# Altium schematic sheet [03] - MCU and Conn.SchDoc (continued)

## Library data of the parts
ANT1 = 2450AT18D0100E: RF ANT 2.4GHZ CHIP SOLDER SMD; Manufacturer: Johanson; Bandwidth: 100MHz; Frequency: 2.45GHz; Gain: -1dB; Impedance: 50R; Max Power Dissipation: 2W; Number of Bands: 1; Return Loss: -10dB; Case/Package: SMD/SMT; Category: RF Antennas; Component Kind: Standard; ComponentLink1Description: https://datasheet.ciiva.com/29647/2450at18d0100-29647392.pdf?src-supplier=Digi-Key; ComponentLink1URL: https://datasheet.ciiva.com/29647/2450at18d0100-29647392.pdf?src-supplier=Digi-Key; ComponentLink2Description: https://datasheet.ciiva.com/24046/antenna-2450at18d0100-v3-258090-24046431.pdf?src-supplier=Mouser; ComponentLink2URL: https://datasheet.ciiva.com/24046/antenna-2450at18d0100-v3-258090-24046431.pdf?src-supplier=Mouser; Height: 0.047inch; Length: 3.2mm; Library Name: Schlib-master.SchLib; Manufacturer Part Number: 2450AT18D0100E; Mount: Surface Mount; Package Quantity: 3000; Packaging: Tape and Reel; Power Rating: 2W; Pricing 1: 1=0.67000, 10=0.58900, 25=0.55360, 100=0.45190, 250=0.41972, 500=0.35720, 1000=0.28576 (USD); Radiation Hardening: No; RoHS: Yes; RoHS Compliant: Yes; Series: -; Stock 1: 488138; Supplier 1: Digi-Key; Supplier Part Number 1: 712-1573-1-ND; Termination: Solder; Width: 1.6mm
C1 C4 C12 C16 = CL05A475MP5NRNC: CAP CER 4.7UF 10V X5R 0402; Manufacturer: Samsung; Tolerance: 20%; Capacitance: 4.7uF; Case Code (Imperial): 0402; Case/Package: 0402; Category: Ceramic Capacitors; Component Kind: Standard; ComponentLink1Description: https://datasheet.ciiva.com/25786/cl05a475mp5nrnc-25786963.pdf?src-supplier=Digi-Key; ComponentLink1URL: https://datasheet.ciiva.com/25786/cl05a475mp5nrnc-25786963.pdf?src-supplier=Digi-Key; ComponentLink2Description: https://datasheet.ciiva.com/16437/cl05b104kq5nnnc-16437353.pdf?src-supplier=Future+Electronics; ComponentLink2URL: https://datasheet.ciiva.com/16437/cl05b104kq5nnnc-16437353.pdf?src-supplier=Future+Electronics; Dielectric: X5R; Lead Free: Lead Free; Length: 0.039inch; Library Name: Schlib-master.SchLib; Manufacturer Part Number: CL05A475MP5NRNC; Max Operating Temperature: 85°C; Min Operating Temperature: -55°C; Mount: Surface Mount; Package Quantity: 10000; Packaging: Tape and Reel; Pricing 1: 1=0.10000, 10=0.06800, 50=0.03680, 100=0.03010, 500=0.02150, 1000=0.01689, 2500=0.01535, 5000=0.01412 (USD); RoHS: Yes; RoHS Compliant: Yes; Series: CL; Stock 1: 6997940; Supplier 1: Digi-Key; Supplier Part Number 1: 1276-1482-1-ND; Thickness: 0.022inch; Voltage Rating: 10V; Width: 0.02inch
C2 C5 C6 C13-C15 C18 C22 = GRM155R71H104KE14D: CAP CER 0.1UF 50V X7R 0402; Manufacturer: Murata; Dielectric Material: Ceramic; Dissipation Factor: 0.025%; Tolerance: 10%; Capacitance: 100nF; Case Code (Imperial): 0402; Case/Package: 0402; Category: Ceramic Capacitors; Component Kind: Standard; ComponentLink1Description: http://www.mouser.com/ds/2/281/c02e-2905.pdf?src-supplier=Mouser; ComponentLink1URL: http://www.mouser.com/ds/2/281/c02e-2905.pdf?src-supplier=Mouser; ComponentLink2Description: http://www.farnell.com/datasheets/2079156.pdf?src-supplier=Farnell; ComponentLink2URL: http://www.farnell.com/datasheets/2079156.pdf?src-supplier=Farnell; ComponentLink3Description: http://components.arrow.com/part/dataview/0323_07030614?src-supplier=Arrow+Europe; ComponentLink3URL: http://components.arrow.com/part/dataview/0323_07030614?src-supplier=Arrow+Europe; ComponentLink4Description: http://psearch.en.murata.com/capacitor/product/GRM155R71H104KE14%23.pdf?src-supplier=Avnet; ComponentLink4URL: http://psearch.en.murata.com/capacitor/product/GRM155R71H104KE14%23.pdf?src-supplier=Avnet; ComponentLink5Description: https://search.murata.co.jp/Ceramy/image/img/A01X/G101/ENG/GRM155R71H104KE14-01.pdf?src-supplier=Digi-Key; ComponentLink5URL: https://search.murata.co.jp/Ceramy/image/img/A01X/G101/ENG/GRM155R71H104KE14-01.pdf?src-supplier=Digi-Key; ComponentLink6Description: http://www.farnell.com/datasheets/2079156.pdf?src-supplier=Newark; ComponentLink6URL: http://www.farnell.com/datasheets/2079156.pdf?src-supplier=Newark; ComponentLink7Description: http://www.farnell.com/datasheets/2079156.pdf?src-supplier=Element14; ComponentLink7URL: http://www.farnell.com/datasheets/2079156.pdf?src-supplier=Element14; Depth: 0.5mm; Dielectric: X7R; Height: 0.5mm; Lead Free: Lead Free; Length: 1mm; Library Name: Schlib-master.SchLib; Manufacturer Part Number: GRM155R71H104KE14D; Material: Ceramic; Max Operating Temperature: 125°C; Min Operating Temperature: -55°C; Mount: Surface Mount; Package Quantity: 10000; Packaging: Tape and Reel; Pricing 1: 1=0.10000, 10=0.03400, 50=0.01860, 100=0.01520, 500=0.01086, 1000=0.00854, 2500=0.00776, 5000=0.00714 (USD); REACH SVHC: No SVHC; Resistor Type: Ceramic; RoHS: Yes; RoHS Compliant: Yes; Stock 1: 23318607; Supplier 1: Digi-Key; Supplier Part Number 1: 490-10700-1-ND; Termination: SMD/SMT; Thickness: 0.022inch; Voltage: 50V; Voltage Rating: 50V; Voltage Rating (DC): 50V; Weight: 0.000212oz; Width: 0.5mm
C3 C7 C10 C11 C20 = CL05A105KO5NNNC: CAP CER 1UF 16V X5R 0402; Manufacturer: Samsung; Tolerance: 10%; Capacitance: 1uF; Case Code (Imperial): 0402; Case/Package: 0402; Category: Ceramic Capacitors; Component Kind: Standard; ComponentLink1Description: https://datasheet.ciiva.com/16207/cl05a105ko5nnnc-16207571.pdf?src-supplier=Future+Electronics; ComponentLink1URL: https://datasheet.ciiva.com/16207/cl05a105ko5nnnc-16207571.pdf?src-supplier=Future+Electronics; ComponentLink2Description: https://datasheet.ciiva.com/15195/getdatasheetpartid-355272-15195629.pdf?src-supplier=Verical; ComponentLink2URL: https://datasheet.ciiva.com/15195/getdatasheetpartid-355272-15195629.pdf?src-supplier=Verical; Contact Plating: Tin; Depth: 0.5mm; Dielectric: X5R; Height: 0.5mm; Length: 1mm; Library Name: Schlib-master.SchLib; Manufacturer Part Number: CL05A105KO5NNNC; Max Operating Temperature: 85°C; Min Operating Temperature: -55°C; Mount: Surface Mount; Package Quantity: 1; Packaging: Tape and Reel; Pricing 1: 1=0.10000, 10=0.04400, 50=0.02400, 100=0.01960, 500=0.01402, 1000=0.01101, 2500=0.01001, 5000=0.00921 (USD); Radiation Hardening: No; RoHS: Yes; Stock 1: 2455652; Supplier 1: Digi-Key; Supplier Part Number 1: 1276-1067-1-ND; Thickness: 0.022inch; Voltage: 16V; Voltage Rating: 16V; Width: 0.02inch
C8 = GJM1555C1HR80BB01D: CAP CER 0.8PF 50V C0G/NP0 0402; Manufacturer: Murata; Dielectric: C0G; Capacitance: 0.8pF; Case Code (Imperial): 0402; Case/Package: 0402; Category: Ceramic Capacitors; Component Kind: Standard; ComponentLink1Description: https://datasheet.ciiva.com/6270/product-6270516.pdf?src-supplier=Digi-Key; ComponentLink1URL: https://datasheet.ciiva.com/6270/product-6270516.pdf?src-supplier=Digi-Key; ComponentLink2Description: https://datasheet.ciiva.com/18183/getdatasheetpartid-75095-18183863.pdf?src-supplier=Verical; ComponentLink2URL: https://datasheet.ciiva.com/18183/getdatasheetpartid-75095-18183863.pdf?src-supplier=Verical; ComponentLink3Description: https://datasheet.ciiva.com/6270/partnumbering-e-01-6270517.pdf?src-supplier=Digi-Key; ComponentLink3URL: https://datasheet.ciiva.com/6270/partnumbering-e-01-6270517.pdf?src-supplier=Digi-Key; ComponentLink4Description: https://datasheet.ciiva.com/16440/l0005e-16440469.pdf?src-supplier=Future+Electronics; ComponentLink4URL: https://datasheet.ciiva.com/16440/l0005e-16440469.pdf?src-supplier=Future+Electronics; ComponentLink5Description: https://datasheet.ciiva.com/11616/product-11616838.pdf?src-supplier=Avnet; ComponentLink5URL: https://datasheet.ciiva.com/11616/product-11616838.pdf?src-supplier=Avnet; ComponentLink6Description: https://datasheet.ciiva.com/6270/partnumbering-e-01-6270552.pdf?src-supplier=Digi-Key; ComponentLink6URL: https://datasheet.ciiva.com/6270/partnumbering-e-01-6270552.pdf?src-supplier=Digi-Key; Construction: Flat; Depth: 0.5mm; Height: 0.5mm; Lead Free: Lead Free; Length: 1mm; Library Name: Schlib-master.SchLib; Manufacturer Part Number: GJM1555C1HR80BB01D; Max Operating Temperature: 125°C; Min Operating Temperature: -55°C; Mount: Surface Mount; Package Quantity: 1; Packaging: Tape and Reel; Pricing 1: 1=0.20000, 10=0.13900, 50=0.07920, 100=0.06560, 500=0.04596, 1000=0.03805, 2500=0.03542, 5000=0.03240 (USD); Radiation Hardening: No; RoHS: Yes; RoHS Compliant: Yes; Series: GJM; Stock 1: 91134; Supplier 1: Digi-Key; Supplier Part Number 1: 490-6080-1-ND; Termination: SMD/SMT; Thickness: 0.022inch; Voltage: 50V; Voltage Rating: 50V; Voltage Rating (DC): 50V; Width: 0.02inch
C9 = GJM1555C1HR50BB01D: CAP CER 0.5PF 50V C0G/NP0 0402; Manufacturer: Murata; Dielectric: C0G; Temperature Coefficient: 30ppm/°C; Capacitance: 0.5pF; Case Code (Imperial): 0402; Case/Package: 0402; Category: Ceramic Capacitors; Component Kind: Standard; ComponentLink1Description: https://datasheet.ciiva.com/6270/product-6270544.pdf?src-supplier=Digi-Key; ComponentLink1URL: https://datasheet.ciiva.com/6270/product-6270544.pdf?src-supplier=Digi-Key; ComponentLink2Description: https://datasheet.ciiva.com/11789/0900766b80ef921d-11789028.pdf?src-supplier=RSComponents; ComponentLink2URL: https://datasheet.ciiva.com/11789/0900766b80ef921d-11789028.pdf?src-supplier=RSComponents; ComponentLink3Description: https://datasheet.ciiva.com/5694/1700184-5694998.pdf?src-supplier=Farnell; ComponentLink3URL: https://datasheet.ciiva.com/5694/1700184-5694998.pdf?src-supplier=Farnell; ComponentLink4Description: https://datasheet.ciiva.com/18183/getdatasheetpartid-153078-18183862.pdf?src-supplier=Verical; ComponentLink4URL: https://datasheet.ciiva.com/18183/getdatasheetpartid-153078-18183862.pdf?src-supplier=Verical; ComponentLink5Description: https://datasheet.ciiva.com/8030/1303334-8030134.pdf?src-supplier=Element14; ComponentLink5URL: https://datasheet.ciiva.com/8030/1303334-8030134.pdf?src-supplier=Element14; ComponentLink6Description: https://datasheet.ciiva.com/16392/l0005e-16392585.pdf?src-supplier=Future+Electronics; ComponentLink6URL: https://datasheet.ciiva.com/16392/l0005e-16392585.pdf?src-supplier=Future+Electronics; ComponentLink7Description: https://datasheet.ciiva.com/17451/product-17451306.pdf?src-supplier=Avnet+Europe; ComponentLink7URL: https://datasheet.ciiva.com/17451/product-17451306.pdf?src-supplier=Avnet+Europe; ComponentLink8Description: https://datasheet.ciiva.com/11617/product-11617149.pdf?src-supplier=Avnet; ComponentLink8URL: https://datasheet.ciiva.com/11617/product-11617149.pdf?src-supplier=Avnet; ComponentLink9Description: https://datasheet.ciiva.com/6270/partnumbering-e-01-6270543.pdf?src-supplier=Digi-Key; ComponentLink9URL: https://datasheet.ciiva.com/6270/partnumbering-e-01-6270543.pdf?src-supplier=Digi-Key; Construction: Flat; Depth: 0.5mm; Height: 0.5mm; Lead Free: Lead Free; Lead Pitch: 0.3mm; Length: 1mm; Library Name: Schlib-master.SchLib; Manufacturer Part Number: GJM1555C1HR50BB01D; Max Operating Temperature: 125°C; Min Operating Temperature: -55°C; Mount: Surface Mount; Number of Pins: 2; Package Quantity: 1; Packaging: Tape and Reel; Pricing 1: 1=0.20000, 10=0.13900, 50=0.07920, 100=0.06560, 500=0.04596, 1000=0.03805, 2500=0.03542, 5000=0.03240 (USD); Radiation Hardening: No; REACH SVHC: No SVHC; RoHS: Yes; RoHS Compliant: Yes; Series: GJM; Stock 1: 81547; Supplier 1: Digi-Key; Supplier Part Number 1: 490-6077-1-ND; Termination: SMD/SMT; Thickness: 0.022inch; Voltage: 50V; Voltage Rating: 50V; Voltage Rating (DC): 50V; Width: 0.02inch
C17 C23 = GRM1555C1H8R0DA01D: CAP CER 8PF 50V C0G/NP0 0402; Manufacturer: Murata; Dielectric: C0G; Material: Ceramic; Temperature Coefficient: 30ppm/°C; Capacitance: 8pF; Case Code (Imperial): 0402; Case/Package: 0402; Category: Ceramic Capacitors; Component Kind: Standard; ComponentLink1Description: https://datasheet.ciiva.com/5420/product-5420945.pdf?src-supplier=Mouser; ComponentLink1URL: https://datasheet.ciiva.com/5420/product-5420945.pdf?src-supplier=Mouser; ComponentLink2Description: https://datasheet.ciiva.com/18184/getdatasheetpartid-713257-18184503.pdf?src-supplier=Verical; ComponentLink2URL: https://datasheet.ciiva.com/18184/getdatasheetpartid-713257-18184503.pdf?src-supplier=Verical; ComponentLink3Description: https://datasheet.ciiva.com/6277/partnumbering-e-01-6277815.pdf?src-supplier=Digi-Key; ComponentLink3URL: https://datasheet.ciiva.com/6277/partnumbering-e-01-6277815.pdf?src-supplier=Digi-Key; ComponentLink4Description: https://datasheet.ciiva.com/6277/partnumbering-e-01-6277814.pdf?src-supplier=Digi-Key; ComponentLink4URL: https://datasheet.ciiva.com/6277/partnumbering-e-01-6277814.pdf?src-supplier=Digi-Key; ComponentLink5Description: https://datasheet.ciiva.com/6277/partnumbering-e-01-6277816.pdf?src-supplier=Digi-Key; ComponentLink5URL: https://datasheet.ciiva.com/6277/partnumbering-e-01-6277816.pdf?src-supplier=Digi-Key; ComponentLink6Description: https://datasheet.ciiva.com/11463/product-11463855.pdf?src-supplier=Avnet; ComponentLink6URL: https://datasheet.ciiva.com/11463/product-11463855.pdf?src-supplier=Avnet; Construction: Flat; Depth: 0.5mm; Height: 0.5mm; Lead Free: Lead Free; Length: 1mm; Library Name: Schlib-master.SchLib; Manufacturer Part Number: GRM1555C1H8R0DA01D; Max Operating Temperature: 125°C; Min Operating Temperature: -55°C; Mount: Surface Mount; Package Quantity: 1; Packaging: Tape and Reel; Pricing 1: 1=0.10000, 10=0.03400, 50=0.01840, 100=0.01510, 500=0.01078, 1000=0.00847, 2500=0.00770, 5000=0.00708 (USD); Radiation Hardening: No; Reach SVHC Compliant: Yes; RoHS: Yes; RoHS Compliant: Yes; Series: GRM; Stock 1: 709625; Supplier 1: Digi-Key; Supplier Part Number 1: 490-5867-1-ND; Termination: SMD/SMT; Thickness: 0.022inch; Voltage: 50V; Voltage Rating: 50V; Voltage Rating (DC): 50V; Width: 0.5mm
C19 = CL05B102KB5NNNC: CAP CER 1000PF 50V X7R 0402; Manufacturer: Samsung; Material: Ceramic; Tolerance: 10%; Capacitance: 1nF; Case Code (Imperial): 0402; Case/Package: 0402; Category: Ceramic Capacitors; Component Kind: Standard; ComponentLink1Description: https://datasheet.ciiva.com/25806/cl05b102kb5nnnc-25806896.pdf?src-supplier=Digi-Key; ComponentLink1URL: https://datasheet.ciiva.com/25806/cl05b102kb5nnnc-25806896.pdf?src-supplier=Digi-Key; ComponentLink2Description: https://datasheet.ciiva.com/15227/getdatasheetpartid-355276-15227190.pdf?src-supplier=Verical; ComponentLink2URL: https://datasheet.ciiva.com/15227/getdatasheetpartid-355276-15227190.pdf?src-supplier=Verical; ComponentLink3Description: https://datasheet.ciiva.com/29198/459222-da-01-en-vs-kondensator-0402-29198191.pdf?src-supplier=Conrad; ComponentLink3URL: https://datasheet.ciiva.com/29198/459222-da-01-en-vs-kondensator-0402-29198191.pdf?src-supplier=Conrad; ComponentLink4Description: https://datasheet.ciiva.com/26309/mlcc-catalogdownload2013-eng-26309020.pdf?src-supplier=Distrelec; ComponentLink4URL: https://datasheet.ciiva.com/26309/mlcc-catalogdownload2013-eng-26309020.pdf?src-supplier=Distrelec; Contact Plating: Tin; Depth: 0.5mm; Dielectric: X7R; Height: 0.5mm; Lead Free: Lead Free; Length: 1mm; Library Name: Schlib-master.SchLib; Manufacturer Part Number: CL05B102KB5NNNC; Max Operating Temperature: 125°C; Min Operating Temperature: -55°C; Mount: Surface Mount; Package Quantity: 1; Packaging: Tape and Reel; Pricing 1: 1=0.10000, 10=0.03300, 50=0.01800, 100=0.01480, 500=0.01054, 1000=0.00829, 2500=0.00754, 5000=0.00693 (USD); Radiation Hardening: No; RoHS: Yes; Stock 1: 12036728; Supplier 1: Digi-Key; Supplier Part Number 1: 1276-1032-6-ND; Thickness: 0.022inch; Voltage: 50V; Voltage Rating: 50V; Voltage Rating (DC): 50V; Width: 0.02inch
C21 = CL05C101JB5NNNC: CAP CER 100PF 50V C0G/NP0 0402; Manufacturer: Samsung; Dielectric: C0G; Material: Ceramic; Temperature Coefficient: 300ppm/°C; Tolerance: 5%; Capacitance: 100pF; Case Code (Imperial): 0402; Case/Package: 0402; Category: Ceramic Capacitors; Component Kind: Standard; ComponentLink1Description: https://datasheet.ciiva.com/15195/getdatasheetpartid-357528-15195665.pdf?src-supplier=Verical; ComponentLink1URL: https://datasheet.ciiva.com/15195/getdatasheetpartid-357528-15195665.pdf?src-supplier=Verical; ComponentLink2Description: https://datasheet.ciiva.com/29198/458492-da-01-en-vs-kondensator-0402-29198529.pdf?src-supplier=Conrad; ComponentLink2URL: https://datasheet.ciiva.com/29198/458492-da-01-en-vs-kondensator-0402-29198529.pdf?src-supplier=Conrad; ComponentLink3Description: https://datasheet.ciiva.com/16379/cl05b104kq5nnnc-16379226.pdf?src-supplier=Future+Electronics; ComponentLink3URL: https://datasheet.ciiva.com/16379/cl05b104kq5nnnc-16379226.pdf?src-supplier=Future+Electronics; Construction: Flat; Contact Plating: Tin; Depth: 0.5mm; Height: 0.5mm; Length: 1mm; Library Name: Schlib-master.SchLib; Manufacturer Part Number: CL05C101JB5NNNC; Max Operating Temperature: 125°C; Min Operating Temperature: -55°C; Mount: Surface Mount; Package Quantity: 10000; Packaging: Tape and Reel; Pricing 1: 1=0.10000, 10=0.02000, 50=0.01080, 100=0.00880, 500=0.00628, 1000=0.00493, 2500=0.00448, 5000=0.00412 (USD); Radiation Hardening: No; RoHS: Yes; RoHS Compliant: Yes; Series: CL; Stock 1: 4859529; Supplier 1: Digi-Key; Supplier Part Number 1: 1276-1025-1-ND; Thickness: 0.022inch; Voltage: 50V; Voltage Rating: 50V; Width: 0.02inch
D1 = CUHS20F30,H3F: DIODE SCHOTTKY 30V 2A US2H; Manufacturer: Toshiba; Component Kind: Standard; Library Name: Schlib-master.SchLib; Manufacturer Part Number: CUHS20F30,H3F; Pricing 1: 1=0.37000, 10=0.30200, 100=0.20540, 500=0.15408, 1000=0.11556 (USD); RoHS: No; Stock 1: 70970; Supplier 1: Digi-Key; Supplier Part Number 1: CUHS20F30H3FCT-ND
D2 D3 = TPESD0201G05V: TVS DIODE 5.5VWM 40VC 0201; Manufacturer: TECH PUBLIC; Clamping Voltage: 40V; Number of Bidirectional Channels: 1; Peak Pulse Current: 3A; Power Line Protection: No; Reverse Standoff Voltage: 5.5V; Case/Package: SMD/SMT; Category: Diodes; Component Kind: Standard; ComponentLink1Description: https://datasheet.lcsc.com/lcsc/2005211235_TECH-PUBLIC-TPESD0201G15V_C558460.pdf; ComponentLink1URL: https://datasheet.lcsc.com/lcsc/2005211235_TECH-PUBLIC-TPESD0201G15V_C558460.pdf; Halogen Free: Halogen Free; Lead Free: Lead Free; Library Name: Schlib-master.SchLib; Manufacturer Part Number: TPESD0201G05V; Max Operating Temperature: 125°C; Min Operating Temperature: -55°C; Mount: Surface Mount; Packaging: Tape and Reel; Resistor Type: Zener; RoHS: Yes; RoHS Compliant: Yes
D4 = 19-217/G7C-AN1P2/3T: LED YLW/GREEN DIFFUSED 1608 SMD; Manufacturer: Everlight; Color: Green,Yellow; Forward Voltage: 2V; Lens Style: Clear,Diffused,Colorless,Rectangular; Number of LEDs: 1; Viewing Angle: 120°; Category: LEDs; Component Kind: Standard; ComponentLink1Description: https://datasheet.ciiva.com/48679/19-217-g7c-an1p2-3t-48679179.pdf?src-supplier=Avnet; ComponentLink1URL: https://datasheet.ciiva.com/48679/19-217-g7c-an1p2-3t-48679179.pdf?src-supplier=Avnet; Lead Free: Lead Free; Library Name: Schlib-master.SchLib; Manufacturer Part Number: 19-217/G7C-AN1P2/3T; Mounting Technology: Surface Mount; Pins: 2; Pricing 1: 2635=0.01900, 6250=0.01600, 10000=0.01500, 13335=0.01500, 17860=0.01400, 23080=0.01300 (USD); RoHS: Yes; RoHS Compliant: Yes; Stock 1: 152500; Supplier 1: Win Source Electronics; Supplier Part Number 1: 5203-19-217/G7C-AN1P2/3T
J1 = TYPEC-324G-BCP24: CONN RCPT USB TYPE C, SMT PINS, TH SHIELD; Manufacturer: XUNPU; Category: USB Connectors; Component Kind: Standard; ComponentLink1Description: https://www.lcsc.com/product-detail/USB-Connectors_XUNPU-TYPEC-324G-BCP24_C920230.html; ComponentLink1URL: https://www.lcsc.com/product-detail/USB-Connectors_XUNPU-TYPEC-324G-BCP24_C920230.html; Library Name: Schlib-master.SchLib; Manufacturer Part Number: TYPEC-324G-BCP24
L1 = LQG15HN4N7S02D: FIXED IND 4.7NH 700MA 230 MOHM; Manufacturer: Murata; Core Material: Air; Current Rating: 300mA; DC Resistance (DCR): 230mR; Inductor Type: MONOLITHIC; Q Factor: 8; Self Resonant Frequency: 6GHz; Shielding: Unshielded; Test Frequency: 100MHz; Case Code (Imperial): 0402; Case Code (Metric): 1005; Case/Package: 0402; Category: Fixed Inductors; Component Kind: Standard; ComponentLink1Description: https://datasheet.ciiva.com/11801/0900766b80f10808-11801428.pdf?src-supplier=RSComponents; ComponentLink1URL: https://datasheet.ciiva.com/11801/0900766b80f10808-11801428.pdf?src-supplier=RSComponents; ComponentLink2Description: https://datasheet.ciiva.com/8509/l0075s0083lqg15hn-8509689.pdf?src-supplier=Avnet; ComponentLink2URL: https://datasheet.ciiva.com/8509/l0075s0083lqg15hn-8509689.pdf?src-supplier=Avnet; ComponentLink3Description: https://datasheet.ciiva.com/4976/o05e-4976780.pdf?src-supplier=Mouser; ComponentLink3URL: https://datasheet.ciiva.com/4976/o05e-4976780.pdf?src-supplier=Mouser; ComponentLink4Description: https://datasheet.ciiva.com/8002/66026-8002543.pdf?src-supplier=Element14; ComponentLink4URL: https://datasheet.ciiva.com/8002/66026-8002543.pdf?src-supplier=Element14; ComponentLink5Description: https://datasheet.ciiva.com/1063/l0075s0083lqg15hn-1063843.pdf?src-supplier=Digi-Key; ComponentLink5URL: https://datasheet.ciiva.com/1063/l0075s0083lqg15hn-1063843.pdf?src-supplier=Digi-Key; ComponentLink6Description: https://datasheet.ciiva.com/8510/l0075s0083lqg15hn-8510764.pdf?src-supplier=Avnet; ComponentLink6URL: https://datasheet.ciiva.com/8510/l0075s0083lqg15hn-8510764.pdf?src-supplier=Avnet; DC Current: 300mA; Depth: 0.55mm; Height: 0.02inch; Height - Seated (Max): 0.022inch; Inductance: 4.7nH; Lead Free: Lead Free; Length: 1mm; Library Name: Schlib-master.SchLib; Manufacturer Part Number: LQG15HN4N7S02D; Max DC Current: 300mA; Max Operating Temperature: 125°C; Military Standard: Not; Min Operating Temperature: -55°C; Mount: Surface Mount; Number of Pins: 2; Package Quantity: 10000; Packaging: Tape and Reel; Pricing 1: 1=0.10000, 10=0.05300, 25=0.04680, 50=0.03980, 100=0.03510, 250=0.03216, 500=0.02924, 1000=0.02457, 2500=0.02106 (USD); Radiation Hardening: No; Rated Current-Max: 300mA; REACH SVHC: No SVHC; RoHS: Yes; RoHS Compliant: Yes; Series: LQG15HS; Stock 1: 37375; Supplier 1: Digi-Key; Supplier Part Number 1: 490-1080-1-ND; Termination: SMD/SMT; Width: 0.02inch
OSC1 = SIT1532AC-J5-DCC-32.768E: MEMS OSC XO 32.7680KHZ LVCMOS; Manufacturer: SiTime; Frequency: 32.768kHz; Frequency Stability: 75ppm; Max Supply Current: 1.3uA; Category: Oscillators; Component Kind: Standard; ComponentLink1Description: http://www.sitime.com/products/datasheets/sit1532/SiT1532-datasheet.pdf?src-supplier=Digi-Key; ComponentLink1URL: http://www.sitime.com/products/datasheets/sit1532/SiT1532-datasheet.pdf?src-supplier=Digi-Key; ComponentLink2Description: https://www.sitime.com/datasheet/SiT1532?src-supplier=Digi-Key; ComponentLink2URL: https://www.sitime.com/datasheet/SiT1532?src-supplier=Digi-Key; Height: 0.024inch; Length: 0.061inch; Library Name: Schlib-master.SchLib; Manufacturer Part Number: SIT1532AC-J5-DCC-32.768E; Max Operating Temperature: 70°C; Min Operating Temperature: -10°C; Mount: Surface Mount; Packaging: Tape and Reel; Pricing 1: 1=1.25000, 10=1.10300, 50=1.03120, 100=0.91120, 500=0.86328 (USD); RoHS: Yes; Stock 1: 4488; Supplier 1: Digi-Key; Supplier Part Number 1: 1473-1313-1-ND; Width: 0.033inch
Q1 = EM6M2T2R: MOSFET N/P-CH 20V 0.2A EMT6; Manufacturer: Rohm; Continuous Drain Current (ID): 200mA; Drain to Source Resistance: 1R; FET Type: N and P-Channel; Input Capacitance: 25pF; Max Power Dissipation: 150mW; Case/Package: SOT-23-5; Category: MOSFETs; Component Kind: Standard; ComponentLink1Description: https://datasheet.ciiva.com/6387/em6m2-6387413.pdf?src-supplier=Digi-Key; ComponentLink1URL: https://datasheet.ciiva.com/6387/em6m2-6387413.pdf?src-supplier=Digi-Key; ComponentLink2Description: https://datasheet.ciiva.com/6387/em6m2-6387415.pdf?src-supplier=Digi-Key; ComponentLink2URL: https://datasheet.ciiva.com/6387/em6m2-6387415.pdf?src-supplier=Digi-Key; ComponentLink3Description: https://datasheet.ciiva.com/6387/em6m2-6387417.pdf?src-supplier=Digi-Key; ComponentLink3URL: https://datasheet.ciiva.com/6387/em6m2-6387417.pdf?src-supplier=Digi-Key; Drain to Source Voltage (Vdss): 20V; Drain-source On Resistance-Max: 1R; Lead Free: Lead Free; Library Name: Schlib-master.SchLib; Manufacturer Part Number: EM6M2T2R; Max Operating Temperature: 150°C; Min Operating Temperature: -55°C; Mounting Technology: Surface Mount; Package Quantity: 1; Packaging: Tape and Reel; Pins: 6; Pricing 1: 1=0.58000, 10=0.49600, 100=0.37030, 500=0.29096, 1000=0.22482, 2000=0.20499 (USD); Radiation Hardening: No; Rds On Max: 1R; RoHS: Yes; RoHS Compliant: Yes; Stock 1: 90900; Supplier 1: Digi-Key; Supplier Part Number 1: EM6M2T2RCT-ND
Q2 = EM6K7T2R: MOSFET 2N-CH 20V 0.2A EMT6; Manufacturer: Rohm; Continuous Drain Current (ID): 200mA; Drain to Source Resistance: 1.2R; Fall Time: 10ns; FET Type: 2 N-Channel; Gate to Source Voltage (Vgs): 8V; Input Capacitance: 25pF; Max Power Dissipation: 150mW; Turn-Off Delay Time: 15ns; Turn-On Delay Time: 5ns; Category: MOSFETs; Component Kind: Standard; ComponentLink1Description: https://datasheet.ciiva.com/6387/em6k7-6387446.pdf?src-supplier=Digi-Key; ComponentLink1URL: https://datasheet.ciiva.com/6387/em6k7-6387446.pdf?src-supplier=Digi-Key; ComponentLink2Description: https://datasheet.ciiva.com/13051/em6k7-209726-13051863.pdf?src-supplier=Mouser; ComponentLink2URL: https://datasheet.ciiva.com/13051/em6k7-209726-13051863.pdf?src-supplier=Mouser; ComponentLink3Description: https://datasheet.ciiva.com/11162/em6k7-11162107.pdf?src-supplier=Avnet; ComponentLink3URL: https://datasheet.ciiva.com/11162/em6k7-11162107.pdf?src-supplier=Avnet; ComponentLink4Description: https://datasheet.ciiva.com/6387/em6k7-6387451.pdf?src-supplier=Digi-Key; ComponentLink4URL: https://datasheet.ciiva.com/6387/em6k7-6387451.pdf?src-supplier=Digi-Key; ComponentLink5Description: https://datasheet.ciiva.com/16437/em6k7-16437677.pdf?src-supplier=Future+Electronics; ComponentLink5URL: https://datasheet.ciiva.com/16437/em6k7-16437677.pdf?src-supplier=Future+Electronics; Drain to Source Voltage (Vdss): 20V; Drain-source On Resistance-Max: 1.2R; Lead Free: Lead Free; Library Name: Schlib-master.SchLib; Manufacturer Part Number: EM6K7T2R; Max Operating Temperature: 150°C; Min Operating Temperature: -55°C; Mounting Technology: Surface Mount; Package Quantity: 8000; Packaging: Tape and Reel; Pins: 6; Pricing 1: 1=0.54000, 10=0.43900, 100=0.29900, 500=0.22428, 1000=0.16821, 2000=0.15419 (USD); Radiation Hardening: No; Rds On Max: 1.2R; Rise Time: 10ns; RoHS: Yes; RoHS Compliant: Yes; Stock 1: 15154; Supplier 1: Digi-Key; Supplier Part Number 1: EM6K7T2RCT-ND
R1 R2 = RC0402FR-075K1L: RES SMD 5.1K OHM 1% 1/16W 0402; Manufacturer: Yageo; Max Power Dissipation: 63mW; Resistance: 5.1kR; Temperature Coefficient: 100ppm/°C; Voltage Rating: 50V; Case Code (Imperial): 0402; Case/Package: 0402; Category: Chip SMD Resistors; Component Kind: Standard; ComponentLink1Description: https://datasheet.ciiva.com/2453/pyu-r-int-thick-7-2453400.pdf?src-supplier=Digi-Key; ComponentLink1URL: https://datasheet.ciiva.com/2453/pyu-r-int-thick-7-2453400.pdf?src-supplier=Digi-Key; ComponentLink2Description: https://datasheet.ciiva.com/2453/pyu-r-int-thick-7-2453401.pdf?src-supplier=Digi-Key; ComponentLink2URL: https://datasheet.ciiva.com/2453/pyu-r-int-thick-7-2453401.pdf?src-supplier=Digi-Key; ComponentLink3Description: https://datasheet.ciiva.com/2453/pyu-r-int-thick-7-2453402.pdf?src-supplier=Digi-Key; ComponentLink3URL: https://datasheet.ciiva.com/2453/pyu-r-int-thick-7-2453402.pdf?src-supplier=Digi-Key; ComponentLink4Description: https://datasheet.ciiva.com/12558/pyu-rc0402-51-rohs-l-6-r-8721-12558460.pdf?src-supplier=Mouser; ComponentLink4URL: https://datasheet.ciiva.com/12558/pyu-rc0402-51-rohs-l-6-r-8721-12558460.pdf?src-supplier=Mouser; ComponentLink5Description: https://datasheet.ciiva.com/16744/pyu-rc0402-51-rohs-l-6-r-16744283.pdf?src-supplier=Future+Electronics; ComponentLink5URL: https://datasheet.ciiva.com/16744/pyu-rc0402-51-rohs-l-6-r-16744283.pdf?src-supplier=Future+Electronics; ComponentLink6Description: https://datasheet.ciiva.com/15189/getdatasheetpartid-323636-15189493.pdf?src-supplier=Verical; ComponentLink6URL: https://datasheet.ciiva.com/15189/getdatasheetpartid-323636-15189493.pdf?src-supplier=Verical; ComponentLink7Description: https://datasheet.ciiva.com/7983/1018594-7983519.pdf?src-supplier=Element14; ComponentLink7URL: https://datasheet.ciiva.com/7983/1018594-7983519.pdf?src-supplier=Element14; ComponentLink8Description: https://datasheet.ciiva.com/46394/1018594-46394936.pdf?src-supplier=Element14; ComponentLink8URL: https://datasheet.ciiva.com/46394/1018594-46394936.pdf?src-supplier=Element14; Construction: Rectangular; Depth: 0.5mm; Features: Moisture Resistant; Height: 0.016inch; Lead Free: Lead Free; Length: 1mm; Library Name: Schlib-master.SchLib; Manufacturer Part Number: RC0402FR-075K1L; Max Operating Temperature: 155°C; Military Standard: Not; Min Operating Temperature: -55°C; Number of Terminations: 2; Package Quantity: 1; Packaging: Tape and Reel; Power Rating: 63mW; Pricing 1: 1=0.10000, 10=0.01600, 100=0.00660, 1000=0.00299, 2500=0.00259, 5000=0.00214 (USD); REACH SVHC: Unknown; Resistance Tolerance: 1%; Resistor Type: Thick Film; RoHS: Yes; RoHS Compliant: Yes; Series: RC; Stock 1: 1329359; Supplier 1: Digi-Key; Supplier Part Number 1: 311-5.10KLRCT-ND; Technology: THICK FILM; Termination: SMD/SMT; Tolerance: 1%; Voltage Rating (DC): 50V; Width: 0.02inch; Working Voltage: 50V
R3 R4 R7 R10-R16 = RC0402FR-0710KL: RES SMD 10K OHM 1% 1/16W 0402; Manufacturer: Yageo; Max Power Dissipation: 63mW; Power Rating: 62.5mW; Resistance: 10kR; Temperature Coefficient: 100ppm/°C; Voltage Rating: 50V; Case Code (Imperial): 0402; Case/Package: 0402; Category: Chip SMD Resistors; Component Kind: Standard; ComponentLink1Description: https://datasheet.ciiva.com/7660/38568-7660787.pdf?src-supplier=Element14; ComponentLink1URL: https://datasheet.ciiva.com/7660/38568-7660787.pdf?src-supplier=Element14; ComponentLink2Description: https://datasheet.ciiva.com/7983/1018594-7983486.pdf?src-supplier=Element14; ComponentLink2URL: https://datasheet.ciiva.com/7983/1018594-7983486.pdf?src-supplier=Element14; ComponentLink3Description: https://datasheet.ciiva.com/7849/1784430-7849813.pdf?src-supplier=Element14; ComponentLink3URL: https://datasheet.ciiva.com/7849/1784430-7849813.pdf?src-supplier=Element14; ComponentLink4Description: https://datasheet.ciiva.com/7849/1686128-7849814.pdf?src-supplier=Element14; ComponentLink4URL: https://datasheet.ciiva.com/7849/1686128-7849814.pdf?src-supplier=Element14; ComponentLink5Description: https://datasheet.ciiva.com/7849/1784430-7849815.pdf?src-supplier=Element14; ComponentLink5URL: https://datasheet.ciiva.com/7849/1784430-7849815.pdf?src-supplier=Element14; ComponentLink6Description: https://datasheet.ciiva.com/22747/1018594-22747512.pdf?src-supplier=Element14; ComponentLink6URL: https://datasheet.ciiva.com/22747/1018594-22747512.pdf?src-supplier=Element14; ComponentLink7Description: https://datasheet.ciiva.com/12667/pyu-rc0402-51-rohs-l-6-r-12667067.pdf?src-supplier=Mouser; ComponentLink7URL: https://datasheet.ciiva.com/12667/pyu-rc0402-51-rohs-l-6-r-12667067.pdf?src-supplier=Mouser; Construction: Rectangular; Depth: 0.5mm; Features: Moisture Resistant; Height: 0.32mm; Lead Free: Lead Free; Lead Pitch: 0.2mm; Length: 1mm; Library Name: Schlib-master.SchLib; Manufacturer Part Number: RC0402FR-0710KL; Max Operating Temperature: 155°C; Military Standard: Not; Min Operating Temperature: -55°C; Number of Pins: 2; Number of Terminations: 2; Packaging: Tape and Reel; Pricing 1: 1=0.10000, 10=0.01400, 100=0.00580, 1000=0.00260, 2500=0.00226, 5000=0.00186 (USD); REACH SVHC: No SVHC; Resistance Tolerance: 1%; Resistor Type: Thick Film; RoHS: Yes; RoHS Compliant: Yes; Series: RC; Stock 1: 39209283; Supplier 1: Digi-Key; Supplier Part Number 1: 311-10.0KLRCT-ND; Termination: SMD/SMT; Tolerance: 1%; Voltage Rating (DC): 50V; Width: 0.5mm; Working Voltage: 50V
R5 = NCP15XH103J03RC: THERMISTOR NTC 10KOHM 3380K 0402; Manufacturer: Murata; Accuracy: 0.7%; Category 1: Sensors, Transducers; Category 2: Temperature Sensors - NTC Thermistors; Current Rating: 310uA; Max Power Dissipation: 100mW; Resistance: 10kR; Tolerance: 5%; Case Code (Imperial): 0402; Case/Package: 0402; Category: Thermistors; Component Kind: Standard; ComponentLink1Description: https://datasheet.ciiva.com/22712/1825737-22712084.pdf?src-supplier=Element14; ComponentLink1URL: https://datasheet.ciiva.com/22712/1825737-22712084.pdf?src-supplier=Element14; ComponentLink2Description: https://datasheet.ciiva.com/11822/0900766b807f1e39-11822074.pdf?src-supplier=RSComponents; ComponentLink2URL: https://datasheet.ciiva.com/11822/0900766b807f1e39-11822074.pdf?src-supplier=RSComponents; ComponentLink3Description: https://datasheet.ciiva.com/29387/506183-da-01-en-thermistor-murata-ncp15xh103j03rc-29387260.pdf?src-supplier=Conrad; ComponentLink3URL: https://datasheet.ciiva.com/29387/506183-da-01-en-thermistor-murata-ncp15xh103j03rc-29387260.pdf?src-supplier=Conrad; ComponentLink4Description: https://datasheet.ciiva.com/2848/r44e-2848211.pdf?src-supplier=Digi-Key; ComponentLink4URL: https://datasheet.ciiva.com/2848/r44e-2848211.pdf?src-supplier=Digi-Key; ComponentLink5Description: https://datasheet.ciiva.com/2848/r44e-2848212.pdf?src-supplier=Digi-Key; ComponentLink5URL: https://datasheet.ciiva.com/2848/r44e-2848212.pdf?src-supplier=Digi-Key; ComponentLink6Description: https://datasheet.ciiva.com/2848/r44e-2848213.pdf?src-supplier=Digi-Key; ComponentLink6URL: https://datasheet.ciiva.com/2848/r44e-2848213.pdf?src-supplier=Digi-Key; ComponentLink7Description: https://datasheet.ciiva.com/3282/l0770e-3282046.pdf?src-supplier=Avnet; ComponentLink7URL: https://datasheet.ciiva.com/3282/l0770e-3282046.pdf?src-supplier=Avnet; Depth: 0.5mm; Height: 0.5mm; Lead Free: Lead Free; Length: 1mm; Library Name: Schlib-master.SchLib; Manufacturer 1: Murata; Manufacturer Part Number: NCP15XH103J03RC; Manufacturer Part Number 1: NCP15XH103J03RC; Max Operating Temperature: 125°C; Min Operating Temperature: -40°C; Mount: Surface Mount; Number of Pins: 2; Package Quantity: 1; Packaging: Tape and Reel; Power Rating: 100mW; Pricing 1: 1=0.12000, 5=0.11600, 10=0.10300, 25=0.09520, 50=0.07600, 100=0.06520, 500=0.05706, 1000=0.04891, 5000=0.04347 (USD); Radiation Hardening: No; REACH SVHC: No SVHC; RoHS: Yes; Stock 1: 295142; Supplier 1: Digi-Key; Supplier Part Number 1: 490-2414-1-ND; Termination: SMD/SMT; Width: 0.5mm
R6 = RC0402FR-07360KL: RES SMD 360K OHM 1% 1/16W 0402; Manufacturer: Yageo; Max Power Dissipation: 63mW; Power Rating: 62.5mW; Temperature Coefficient: 100ppm/°C; Value: 360kR; Voltage Rating: 50V; Case Code (Imperial): 0402; Case/Package: 0402; Category: Chip SMD Resistors; Component Kind: Standard; ComponentLink1Description: https://datasheet.ciiva.com/2453/pyu-r-int-thick-7-2453620.pdf?src-supplier=Digi-Key; ComponentLink1URL: https://datasheet.ciiva.com/2453/pyu-r-int-thick-7-2453620.pdf?src-supplier=Digi-Key; ComponentLink2Description: https://datasheet.ciiva.com/2453/pyu-r-int-thick-7-2453621.pdf?src-supplier=Digi-Key; ComponentLink2URL: https://datasheet.ciiva.com/2453/pyu-r-int-thick-7-2453621.pdf?src-supplier=Digi-Key; ComponentLink3Description: https://datasheet.ciiva.com/2453/pyu-r-int-thick-7-2453619.pdf?src-supplier=Digi-Key; ComponentLink3URL: https://datasheet.ciiva.com/2453/pyu-r-int-thick-7-2453619.pdf?src-supplier=Digi-Key; ComponentLink4Description: https://datasheet.ciiva.com/12561/pyu-rc0402-51-rohs-l-6-r-8721-12561150.pdf?src-supplier=Mouser; ComponentLink4URL: https://datasheet.ciiva.com/12561/pyu-rc0402-51-rohs-l-6-r-8721-12561150.pdf?src-supplier=Mouser; ComponentLink5Description: https://datasheet.ciiva.com/8886/pyu-rc0402-51-rohs-l-6-8886029.pdf?src-supplier=Avnet; ComponentLink5URL: https://datasheet.ciiva.com/8886/pyu-rc0402-51-rohs-l-6-8886029.pdf?src-supplier=Avnet; ComponentLink6Description: https://datasheet.ciiva.com/16742/pyu-rc0402-51-rohs-l-6-r-16742217.pdf?src-supplier=Future+Electronics; ComponentLink6URL: https://datasheet.ciiva.com/16742/pyu-rc0402-51-rohs-l-6-r-16742217.pdf?src-supplier=Future+Electronics; Construction: Rectangular; Contact Plating: Tin,Matte; Depth: 0.5mm; Features: Moisture Resistant; Height: 0.016inch; Lead Free: Lead Free; Length: 1mm; Library Name: Schlib-master.SchLib; Manufacturer Part Number: RC0402FR-07360KL; Max Operating Temperature: 155°C; Military Standard: Not; Min Operating Temperature: -55°C; Number of Terminations: 2; Package Quantity: 1; Packaging: Tape and Reel; Pricing 1: 1=0.10000, 10=0.01400, 100=0.00580, 1000=0.00262, 2500=0.00228, 5000=0.00188 (USD); Resistance Tolerance: 1%; Resistor Type: Thick Film; RoHS: Yes; RoHS Compliant: Yes; Series: RC; Stock 1: 662020; Supplier 1: Digi-Key; Supplier Part Number 1: 311-360KLRCT-ND; Termination: SMD/SMT; Tolerance: 1%; Voltage Rating (DC): 50V; Width: 0.02inch
R8 = RC0402FR-0756KL: RES SMD 56K OHM 1% 1/16W 0402; Manufacturer: Yageo; Max Power Dissipation: 63mW; Power Rating: 62.5mW; Resistance: 56kR; Temperature Coefficient: 100ppm/°C; Voltage Rating: 50V; Case Code (Imperial): 0402; Case/Package: 0402; Category: Chip SMD Resistors; Component Kind: Standard; ComponentLink1Description: https://datasheet.ciiva.com/46412/1018594-46412840.pdf?src-supplier=Element14; ComponentLink1URL: https://datasheet.ciiva.com/46412/1018594-46412840.pdf?src-supplier=Element14; ComponentLink2Description: https://datasheet.ciiva.com/2453/pyu-r-int-thick-7-2453479.pdf?src-supplier=Digi-Key; ComponentLink2URL: https://datasheet.ciiva.com/2453/pyu-r-int-thick-7-2453479.pdf?src-supplier=Digi-Key; ComponentLink3Description: https://datasheet.ciiva.com/2453/pyu-r-int-thick-7-2453480.pdf?src-supplier=Digi-Key; ComponentLink3URL: https://datasheet.ciiva.com/2453/pyu-r-int-thick-7-2453480.pdf?src-supplier=Digi-Key; ComponentLink4Description: https://datasheet.ciiva.com/2453/pyu-r-int-thick-7-2453478.pdf?src-supplier=Digi-Key; ComponentLink4URL: https://datasheet.ciiva.com/2453/pyu-r-int-thick-7-2453478.pdf?src-supplier=Digi-Key; ComponentLink5Description: https://datasheet.ciiva.com/5558/pyu-rc0402-51-rohs-l-6-r-5558279.pdf?src-supplier=Mouser; ComponentLink5URL: https://datasheet.ciiva.com/5558/pyu-rc0402-51-rohs-l-6-r-5558279.pdf?src-supplier=Mouser; ComponentLink6Description: https://datasheet.ciiva.com/7850/1018594-7850045.pdf?src-supplier=Element14; ComponentLink6URL: https://datasheet.ciiva.com/7850/1018594-7850045.pdf?src-supplier=Element14; ComponentLink7Description: https://datasheet.ciiva.com/7850/1018594-7850044.pdf?src-supplier=Element14; ComponentLink7URL: https://datasheet.ciiva.com/7850/1018594-7850044.pdf?src-supplier=Element14; Construction: Rectangular; Depth: 0.5mm; Features: Moisture Resistant; Height: 0.016inch; Lead Free: Lead Free; Length: 1mm; Library Name: Schlib-master.SchLib; Manufacturer Part Number: RC0402FR-0756KL; Max Operating Temperature: 155°C; Military Standard: Not; Min Operating Temperature: -55°C; Number of Terminations: 2; Package Quantity: 1; Packaging: Tape and Reel; Pricing 1: 1=0.10000, 10=0.01600, 100=0.00660, 1000=0.00299, 2500=0.00259, 5000=0.00214 (USD); REACH SVHC: No SVHC; Resistance Tolerance: 1%; Resistor Type: Thick Film; RoHS: Yes; RoHS Compliant: Yes; Series: RC; Stock 1: 724545; Supplier 1: Digi-Key; Supplier Part Number 1: 311-56.0KLRCT-ND; Technology: THICK FILM; Termination: SMD/SMT; Tolerance: 1%; Width: 0.02inch; Working Voltage: 50V
R9 = RC0402FR-07510RL: RES SMD 510 OHM 1% 1/16W 0402; Manufacturer: Yageo; Category 1: Resistors; Category 2: Chip Resistor - Surface Mount; Max Power Dissipation: 63mW; Temperature Coefficient: 100ppm/°C; Voltage Rating: 50V; Case Code (Imperial): 0402; Case/Package: 0402; Component Kind: Standard; ComponentLink1Description: https://datasheet.ciiva.com/17063/pyu-rc0402-51-rohs-l-6-r-17063430.pdf?src-supplier=Future+Electronics; ComponentLink1URL: https://datasheet.ciiva.com/17063/pyu-rc0402-51-rohs-l-6-r-17063430.pdf?src-supplier=Future+Electronics; ComponentLink2Description: https://datasheet.ciiva.com/2453/pyu-r-int-thick-7-2453397.pdf?src-supplier=Digi-Key; ComponentLink2URL: https://datasheet.ciiva.com/2453/pyu-r-int-thick-7-2453397.pdf?src-supplier=Digi-Key; ComponentLink3Description: https://datasheet.ciiva.com/2453/pyu-r-int-thick-7-2453398.pdf?src-supplier=Digi-Key; ComponentLink3URL: https://datasheet.ciiva.com/2453/pyu-r-int-thick-7-2453398.pdf?src-supplier=Digi-Key; ComponentLink4Description: https://datasheet.ciiva.com/2453/pyu-r-int-thick-7-2453399.pdf?src-supplier=Digi-Key; ComponentLink4URL: https://datasheet.ciiva.com/2453/pyu-r-int-thick-7-2453399.pdf?src-supplier=Digi-Key; Composition: Thick Film; Depth: 0.5mm; Features: Moisture Resistant; Height: 0.016inch; Lead Free: Lead Free; Length: 1mm; Library Name: Schlib-master.SchLib; Manufacturer 1: Yageo; Manufacturer Part Number: RC0402FR-07510RL; Manufacturer Part Number 1: RC0402FR-07510RL; Max Operating Temperature: 155°C; Military Standard: Not; Min Operating Temperature: -55°C; Mount: Surface Mount; Number of Terminations: 2; Package Quantity: 1; Packaging: Tape and Reel; Power Rating: 63mW; Pricing 1: 1=0.10000, 10=0.01600, 100=0.00640, 1000=0.00289, 2500=0.00251, 5000=0.00207 (USD); REACH SVHC: Unknown; Resistance: 510R; RoHS: Yes; Stock 1: 458379; Supplier 1: Digi-Key; Supplier Part Number 1: 311-510LRCT-ND; Termination: SMD/SMT; Tolerance: 1%; Voltage Rating (DC): 50V; Weight: 2.3E-05oz; Width: 0.5mm
SW1 SW2 = PTS810SJM250SMTRLFS: SWITCH TACTILE SPST-NO 0.05A 16V; Manufacturer: ITT C&K; Actuator Type: Standard; Current Rating: 50mA; Illumination Type: Non-Illuminated; Mechanical Life: 150000Cycles; Operating Force: 160gf; Switch Function: Off-Mom; Switch Travel: 0.15mm; Throw Configuration: SPST; Case/Package: SMD/SMT; Category: Tactile Switches; Color: Black; Component Kind: Standard; ComponentLink1Description: https://datasheet.ciiva.com/24082/pts810-4mar13-248115-24082262.pdf?src-supplier=Mouser; ComponentLink1URL: https://datasheet.ciiva.com/24082/pts810-4mar13-248115-24082262.pdf?src-supplier=Mouser; ComponentLink2Description: https://datasheet.ciiva.com/18514/pts810-4mar13-18514059.pdf?src-supplier=Digi-Key; ComponentLink2URL: https://datasheet.ciiva.com/18514/pts810-4mar13-18514059.pdf?src-supplier=Digi-Key; ComponentLink3Description: https://datasheet.ciiva.com/18514/pts810-4mar13-18514060.pdf?src-supplier=Digi-Key; ComponentLink3URL: https://datasheet.ciiva.com/18514/pts810-4mar13-18514060.pdf?src-supplier=Digi-Key; ComponentLink4Description: https://datasheet.ciiva.com/18514/pts810-4mar13-18514058.pdf?src-supplier=Digi-Key; ComponentLink4URL: https://datasheet.ciiva.com/18514/pts810-4mar13-18514058.pdf?src-supplier=Digi-Key; Contact Plating: Silver; Depth: 4.6mm; Lead Free: Lead Free; Length: 3.2mm; Library Name: Schlib-master.SchLib; Manufacturer Part Number: PTS810SJM250SMTRLFS; Max Current Rating: 50mA; Max Operating Temperature: 85°C; Min Operating Temperature: -40°C; Mount: Surface Mount; Orientation: Straight; Package Quantity: 2900; Packaging: Tape and Reel; Pricing 1: 1=0.36000, 10=0.34400, 25=0.32440, 100=0.28150, 250=0.25536, 500=0.23754, 1000=0.21379 (USD); RoHS: Yes; RoHS Compliant: Yes; Stock 1: 536224; Supplier 1: Digi-Key; Supplier Part Number 1: CKN10502CT-ND; Termination: J-Bend; Voltage Rating (DC): 16V
U1 = BQ25180YBGR: IC 1-A LI-ION IC PROGRAMMABLE CHARG; Manufacturer: Texas Instruments; Component Kind: Standard; ComponentLink1Description: https://www.ti.com/lit/ds/symlink/bq25180.pdf?ts=1664107697804&ref_url=https%253A%252F%252Fwww.ti.com%252Fproduct%252FBQ25180; ComponentLink1URL: https://www.ti.com/lit/ds/symlink/bq25180.pdf?ts=1664107697804&ref_url=https%253A%252F%252Fwww.ti.com%252Fproduct%252FBQ25180; Library Name: Schlib-master.SchLib; Manufacturer Part Number: BQ25180YBGR; Pricing 1: 1=2.78000, 10=2.50000, 25=2.35840, 100=2.00940, 250=1.88680, 500=1.65096, 1000=1.42400 (USD); RoHS: No; Stock 1: 5326; Supplier 1: Digi-Key; Supplier Part Number 1: 296-BQ25180YBGRCT-ND
U2 = 74LVC4066BQ,115: IC SWITCH QUAD SPST 14DHVQFN; Manufacturer: Nexperia; Category: Decoders and Multiplexers; Component Kind: Standard; ComponentLink1Description: https://assets.nexperia.com/documents/data-sheet/74LVC4066.pdf?src-supplier=Digi-Key; ComponentLink1URL: https://assets.nexperia.com/documents/data-sheet/74LVC4066.pdf?src-supplier=Digi-Key; Library Name: Schlib-master.SchLib; Manufacturer Part Number: 74LVC4066BQ,115; Pricing 1: 1=0.67000, 10=0.59300, 25=0.55720, 100=0.45480, 250=0.42248, 500=0.35956, 1000=0.28764 (USD); RoHS: Yes; RoHS Compliant: Yes; Stock 1: 53790; Supplier 1: Digi-Key; Supplier Part Number 1: 1727-3499-1-ND
U3 = NCP167BMX330TBG: IC REG LINEAR 3.3V 700MA 4XDFN; Manufacturer: ON Semiconductor; Category: Voltage Regulators - Linear; Component Kind: Standard; ComponentLink1Description: http://www.farnell.com/datasheets/2711391.pdf?src-supplier=Farnell; ComponentLink1URL: http://www.farnell.com/datasheets/2711391.pdf?src-supplier=Farnell; ComponentLink2Description: https://www.onsemi.com/pub/Collateral/NCP167-D.PDF?src-supplier=Digi-Key; ComponentLink2URL: https://www.onsemi.com/pub/Collateral/NCP167-D.PDF?src-supplier=Digi-Key; ComponentLink3Description: http://www.farnell.com/datasheets/2711391.pdf?src-supplier=Newark; ComponentLink3URL: http://www.farnell.com/datasheets/2711391.pdf?src-supplier=Newark; Library Name: Schlib-master.SchLib; Manufacturer Part Number: NCP167BMX330TBG; Pricing 1: 1=0.61000, 10=0.52500, 25=0.49000, 100=0.39210, 250=0.36408, 500=0.30806, 1000=0.23804 (USD); RoHS: Yes; RoHS Compliant: Yes; Stock 1: 222045; Supplier 1: Digi-Key; Supplier Part Number 1: NCP167BMX330TBGOSCT-ND
U4 = NRF52840-QIAA-R7: IC RF TXRX+MCU 802.15.4 73VFQFN; Manufacturer: Nordic Semiconductor; Category: RF Receivers, Transceivers; Component Kind: Standard; Library Name: Schlib-master.SchLib; Manufacturer Part Number: NRF52840-QIAA-R; Pricing 1: 1=7.21000, 10=6.43500, 25=5.79160, 100=5.27670, 250=4.76192 (USD); Pricing 2: 1=0.44000, 10=0.36400, 50=0.32740, 100=0.29100, 500=0.27644, 1000=0.23280 (USD); RoHS: Yes; RoHS Compliant: Yes; Stock 1: 3157; Stock 2: 16591; Supplier 1: Digi-Key; Supplier 2: Digi-Key; Supplier Part Number 1: 1490-NRF52840-QIAA-R7CT-ND; Supplier Part Number 2: 490-18334-1-ND
U5 = MX25V1635FZUI: IC FLASH 16MBIT SPI/QUAD 8USON; Manufacturer: Macronix; Category: Memory; Component Kind: Standard; Library Name: Schlib-master.SchLib; Manufacturer Part Number: MX25V1635FZUI; Pricing 1: 1=0.78000, 10=0.70700, 25=0.69840, 50=0.69440, 100=0.62090, 250=0.61564, 500=0.60656, 1000=0.58485, 5000=0.53270 (USD); RoHS: Yes; RoHS Compliant: Yes; Stock 1: 133080; Supplier 1: Digi-Key; Supplier Part Number 1: 1092-1264-1-ND
X1 = Q22FA1280002500: CRYSTAL 32.0000MHZ 8PF SMD; Manufacturer: Seiko; Component Kind: Standard; Library Name: Schlib-master.SchLib; Manufacturer Part Number: Q22FA1280002500; Pricing 1: 5=0.17610, 50=0.14280, 150=0.12660, 500=0.11100, 3000=0.10720, 6000=0.10480 (USD); RoHS: No; Stock 1: 25980; Supplier 1: LCSC; Supplier Part Number 1: C187794
J2 J3 = Double_Header_1x11_2.54: Description: Double_Header_1x11_2.54; Library Name: Schlib-master.SchLib
